# Altium schematic sheet Watchdog.SchDoc(Watchdog)
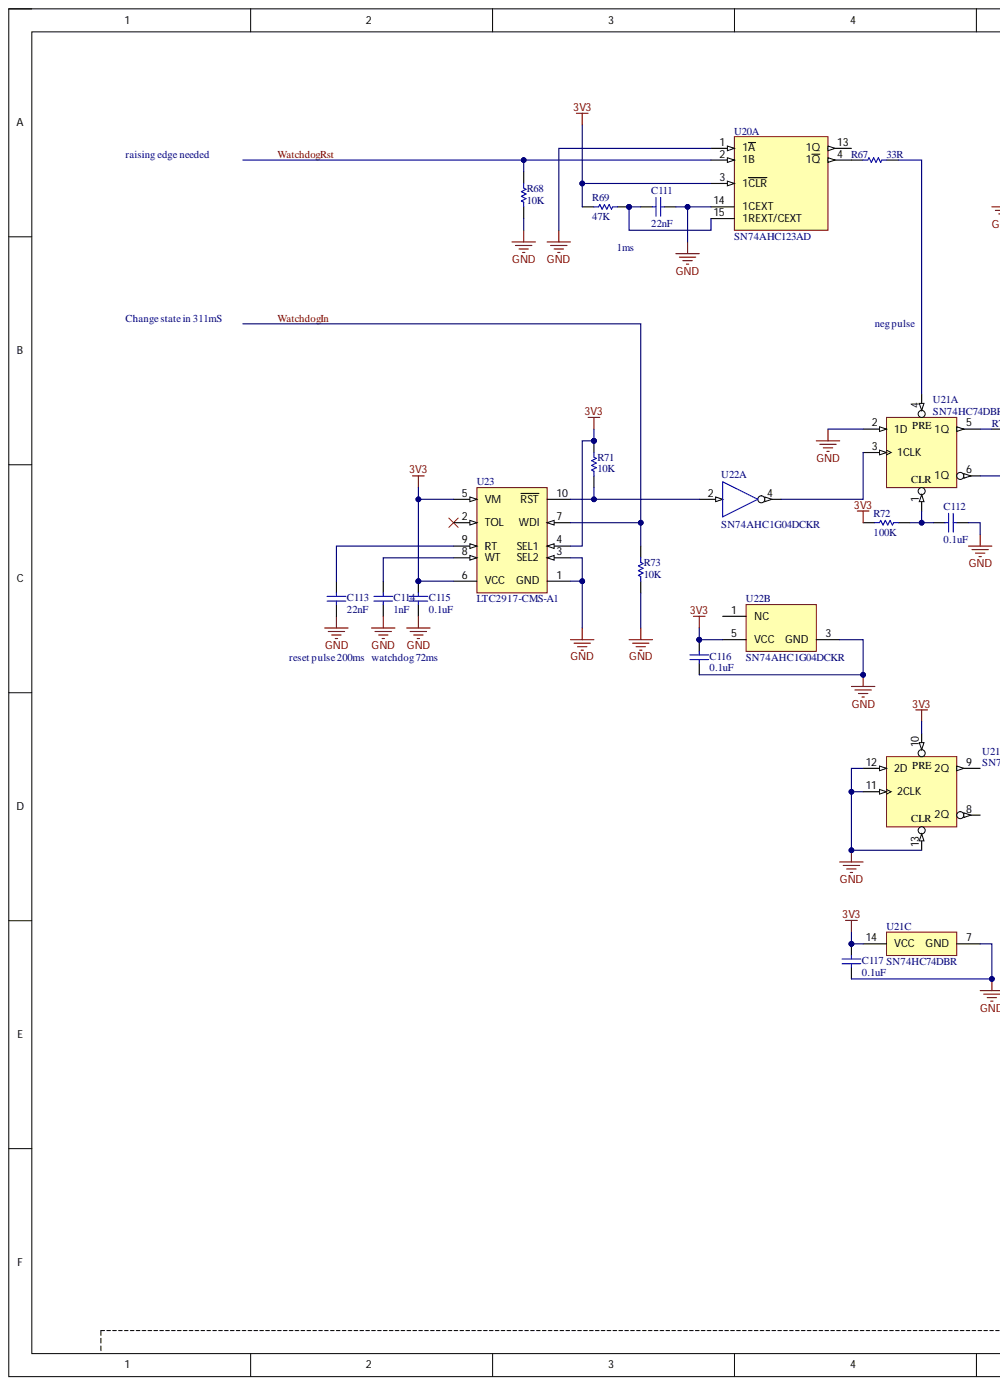
[diagram p0: Watchdog.SchDoc, left]
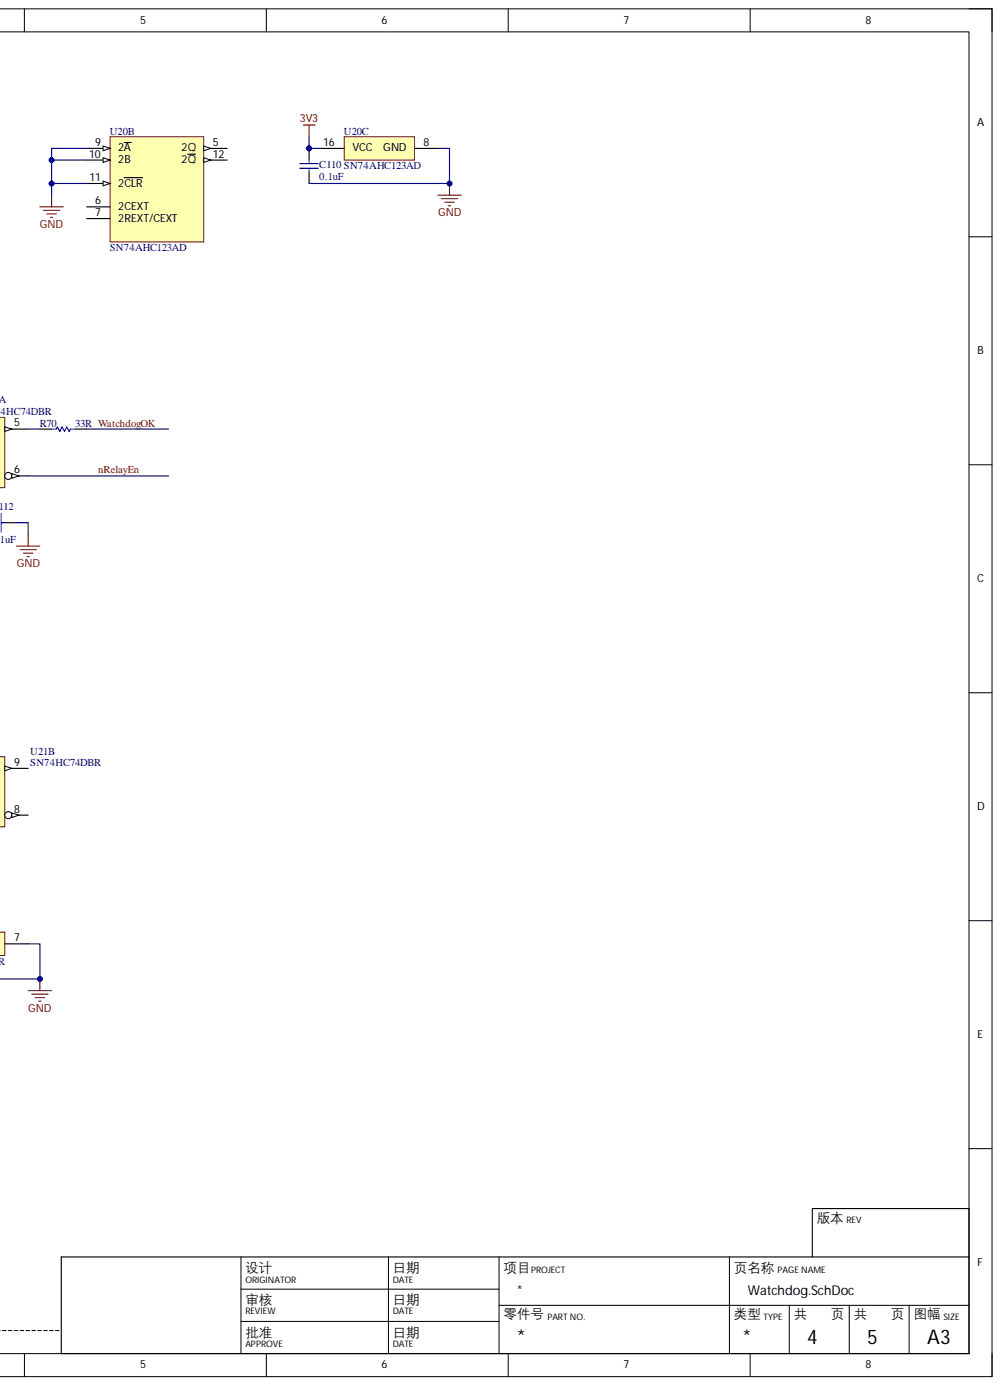
[diagram p1: Watchdog.SchDoc, right]

## Parts
C110  0.1uF  [C0402(1005)]
C111  22nF  [C0402(1005)]
C112  0.1uF  [C0402(1005)]
C113  22nF  [C0402(1005)]
C114  1nF  [C0402(1005)]
C115  0.1uF  [C0402(1005)]
C116  0.1uF  [C0402(1005)]
C117  0.1uF  [C0402(1005)]
R67  33R  [R0402(1005)]
R68  10K  [R0402(1005)]
R69  47K  [R0402(1005)]
R70  33R  [R0402(1005)]
R71  10K  [R0402(1005)]
R72  100K  [R0402(1005)]
R73  10K  [R0402(1005)]
U20  SN74AHC123AD  [SOIC16_N]  (parts A,B,C)
U21  SN74HC74DBR  [SSOP14_N]  (parts A,B,C)
U22  SN74AHC1G04DCKR  [SC70-5]  (parts A,B)
U23  LTC2917-CMS-A1  [MSOP10_N]

## Nets
3V3: C110.2 C115.2 C116.2 C117.2 R69.2 R71.2 R72.2 U20.3(1CLR) U20.16(VCC) U21.10 U21.14(VCC) U22.5(VCC) U23.4(SEL1) U23.5(VM) U23.6(VCC)
GND: C110.1 C111.1 C112.1 C113.2 C114.1 C115.1 C116.1 C117.1 R68.1 R73.1 U20.1(1A) U20.8(GND) U20.9(2A) U20.10(2B) U20.11(2CLR) U20.14(1CEXT) U21.2(1D) U21.7(GND) U21.11(2CLK) U21.12(2D) U21.13 U22.3(GND) U23.1(GND) U23.3(SEL2)
nRelayEn: U21.6(1Q)
WatchdogIn: R73.2 U23.7(WDI)
WatchdogOK: R70.2
WatchdogRst: R68.2 U20.2(1B)
NetC111_2: C111.2 R69.1 U20.15(1REXT/CEXT)
NetC112_2: C112.2 R72.1 U21.1
NetC113_1: C113.1 U23.9(RT)
NetC114_2: C114.2 U23.8(WT)
NetR67_1: R67.1 U20.4(1Q)
NetR67_2: R67.2 U21.4
NetR70_1: R70.1 U21.5(1Q)
NetR71_1: R71.1 U22.2 U23.10(RST)
NetU21_3: U21.3(1CLK) U22.4

Not connected: U20 5(2Q) 6(2CEXT) 7(2REXT/CEXT) 12(2Q) 13(1Q); U21 8(2Q) 9(2Q); U22 1(NC); U23 2(TOL)

## Library data of the parts
C110 C112 C115-C117 = Cap 0.1uF 0402-50V: ceramic 50V; 价格: ¥0.375@100; 制造商: TDK; 型号: C1005X7R1H104K050BB; Component Kind: Standard; Library Name: RCL_release.SchLib; 供应商及库存编号: e络盟 2525047
C111 C113 = Cap 22nF 0402-25V: ceramic 25V; 价格: ¥0.34@100; 制造商: KEMET; 型号: C0402C223K3RACTU; Component Kind: Standard; Library Name: RCL_release.SchLib; 供应商及库存编号: e络盟 2522384
C114 = Cap 1nF 0402-50V: ceramic 50V; 价格: ¥0.376@100; 制造商: KEMET; 型号: C0402C472J3RACTU; Component Kind: Standard; Library Name: RCL_release.SchLib; 供应商及库存编号: e络盟 2678151
R67 R70 = Res 33R 0402: Res ±1%; Component Kind: Standard; Library Name: RCL_release.SchLib
R68 R71 R73 = Res 10K 0402: Res ±1%; Component Kind: Standard; Library Name: RCL_release.SchLib
R69 = Res 47K 0402: Res ±1%; Component Kind: Standard; Library Name: RCL_release.SchLib
R72 = Res 100K 0402: Res ±1%; Component Kind: Standard; Library Name: RCL_release.SchLib
U20 = SN74AHC123AD: DUAL RETRIG MULTIVIB 16-SOIC; 价格: ￥1.87@100; 制造商: Texas Instruments; Component Kind: Standard; Library Name: IC_release.SchLib; 供应商及库存编号: e络盟 1740281; 型号: SN74AHC123AD
U21 = SN74HC74DBR: D-TYPE POS TRG DUAL 14SSOP; 价格: $0.21@100; 制造商: Texas Instruments; Component Kind: Standard; Library Name: IC_release.SchLib; 供应商及库存编号: digikey 296-8370-1-ND; 型号: SN74HC74DBR
U22 = SN74AHC1G04DCKR: SINGLE INVERTER GATE SC70-5; 价格: $0.09@3K; 制造商: Texas Instruments; Component Kind: Standard; Library Name: IC_release.SchLib; 供应商及库存编号: digikey 296-1090-2-ND; 型号: SN74AHC1G04DCKR
U23 = LTC2917-CMS-A1: Component Kind: Standard; Library Name: IC_temp.SchLib
